# Altium schematic sheet Power.SchDoc
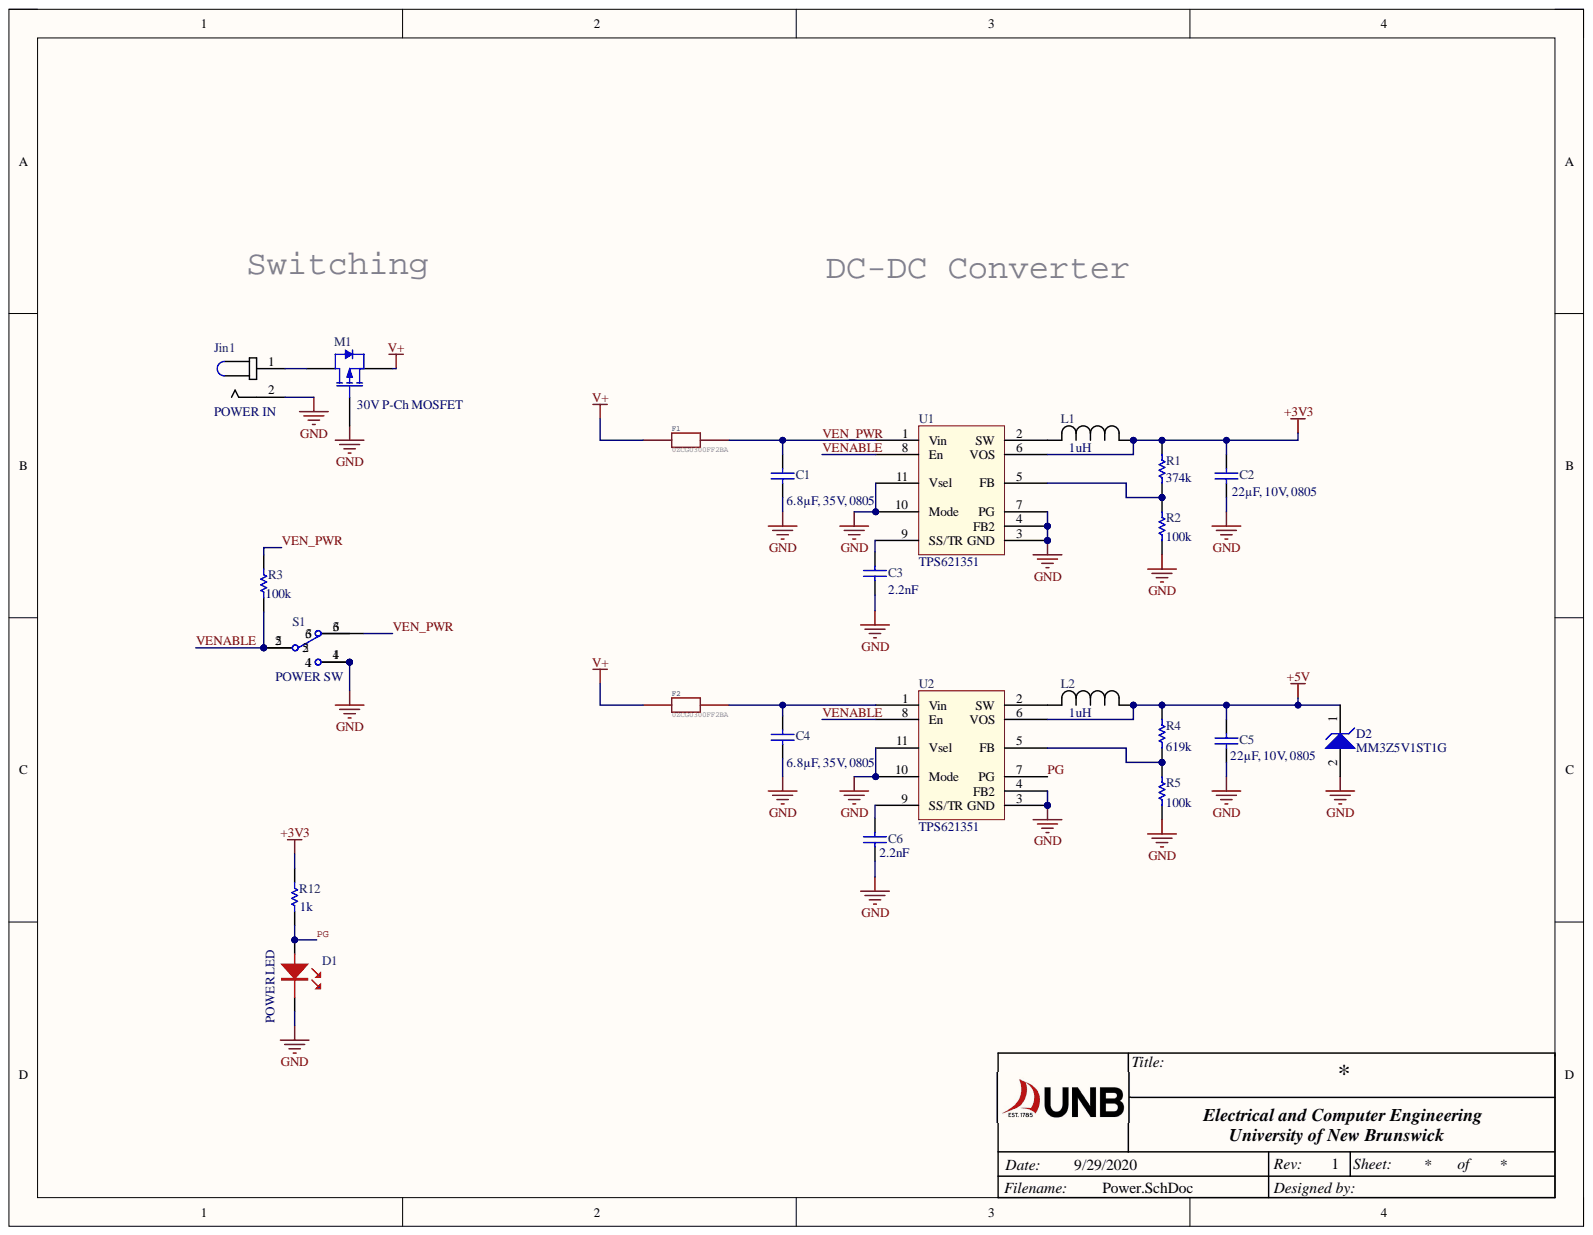
[diagram p0: Power.SchDoc]

## Parts
C1  6.8µF, 35V, 0805  [0805 Cap]
C2  22µF, 10V, 0805  [0805 Cap]
C3  2.2nF  [0603 Cap]
C4  6.8µF, 35V, 0805  [0805 Cap]
C5  22µF, 10V, 0805  [0805 Cap]
C6  2.2nF  [0603 Cap]
D1  POWER LED  [LED_0603_RED]
D2  MM3Z5V1ST1G  [ONSC-SOD-323-2-477-02_V]
F1  0ZCG0300FF2BA  [0ZCF]
F2  0ZCG0300FF2BA  [0ZCF]
Jin1  POWER IN  [CUI_PJ-063AH_PJ-063AH(Primary)]
L1  1uH  [ASPI-0530HI]
L2  1uH  [ASPI-0530HI]
M1  30V P-Ch MOSFET  Drain to Source Voltage (Vdss): 30V  [8-PowerVDFN]
R1  374k  [0603 Resistor]
R2  100k  [0603 Resistor]
R3  100k  [0603 Resistor]
R4  619k  [0603 Resistor]
R5  100k  [0603 Resistor]
R12  1k  [0603 Resistor]
S1  POWER SW  [EG1271A]
U1  TPS621351  [VQFN]
U2  TPS621351  [VQFN]

## Nets
+3V3: C2.2 L1.1 R1.1 R12.2 U1.6(VOS)
+5V: C5.2 D2.1 L2.1 R4.1 U2.6(VOS)
GND: C1.1 C2.1 C3.1 C4.1 C5.1 C6.1 D1.K D2.2 Jin1.2 M1.4 R2.2 R5.2 S1.1 S1.4 U1.3(GND) U1.4(FB2) U1.7(PG) U1.10(Mode) U1.11(Vsel) U2.3(GND) U2.4(FB2) U2.10(Mode) U2.11(Vsel)
V+: F1.P$1 F2.P$1 M1.1
PG: D1.A R12.1 U2.7(PG)
VEN_PWR: C1.2 F1.P$2 R3.1 S1.6 U1.1(Vin)
VENABLE: R3.2 S1.2 S1.5 U1.8(En) U2.8(En)
NetC3_2: C3.2 U1.9(SS/TR)
NetC4_2: C4.2 F2.P$2 U2.1(Vin)
NetC6_2: C6.2 U2.9(SS/TR)
NetJin1_1: Jin1.1 M1.5
NetL1_2: L1.2 U1.2(SW)
NetL2_2: L2.2 U2.2(SW)
NetR1_2: R1.2 R2.1 U1.5(FB)
NetR4_2: R4.2 R5.1 U2.5(FB)

Not connected: S1 3

## Library data of the parts
C1 C4 = Cap Semi: CAP CER 6.8UF 35V X5R 0805; Manufacturer: TDK; Manufacturer Part Number: C2012X5R1V685M125AC; Dielectric Material: Ceramic; Tolerance: 20%; Capacitance: 6.8uF; Case Code (Imperial): 0805; Case Code (Metric): 2012; Case/Package: 0805; Category: Ceramic Capacitors; Component Kind: Standard; ComponentLink1Description: http://www.avx.com/docs/Catalogs/cx7r.pdf; ComponentLink1URL: http://www.avx.com/docs/Catalogs/cx7r.pdf; ComponentLink2Description: https://datasheet.ciiva.com/14894/tdkmlcccaprange-14894343.pdf?src-supplier=Digi-Key; ComponentLink2URL: https://datasheet.ciiva.com/14894/tdkmlcccaprange-14894343.pdf?src-supplier=Digi-Key; ComponentLink3Description: https://datasheet.ciiva.com/14894/tdkmlcccaprange-14894346.pdf?src-supplier=Digi-Key; ComponentLink3URL: https://datasheet.ciiva.com/14894/tdkmlcccaprange-14894346.pdf?src-supplier=Digi-Key; ComponentLink4Description: https://datasheet.ciiva.com/14894/tdkmlcccaprange-14894348.pdf?src-supplier=Digi-Key; ComponentLink4URL: https://datasheet.ciiva.com/14894/tdkmlcccaprange-14894348.pdf?src-supplier=Digi-Key; Depth: 1.25mm; Dielectric: X5R; Lead Free: Lead Free; Length: 0.079inch; Library Name: CAN_Interface_Library.SCHLIB; Max Operating Temperature: 85°C; Min Operating Temperature: -55°C; Mount: Surface Mount; Package Quantity: 2000; Packaging: Tape and Reel; RoHS: Yes; Signal Integrity: Cap Semi; Simulation: CAP; Stock 1: 4110; Supplier 1: Digi-Key; Supplier Part Number 1: 445-14434-1-ND; Thickness: 0.057inch; Value: 6.8µF, 35V, 0805; Voltage Rating: 35V; Voltage Rating (DC): 35V; Width: 0.049inch
C2 C5 = Cap Semi: CAPACITOR, MLCC, X5R, 22UF, 10V, 0805; Product Range:C Series; Capacitance:22 F; Capacitance Tolerance: 20%; Dielectric Characteristic:X5R; Voltage Rating:10V; Ceramic Capacitor Case:0805 [2012 Metric]; Operating Temperature ;RoHS Compliant: Yes; Manufacturer: TDK; Manufacturer Part Number: C2012X5R1A226M085AC; Capacitance: 22uF; Case Code (Imperial): 0805; Case Code (Metric): 2012; Case/Package: 0805; Category: Ceramic Capacitors; Component Kind: Standard; ComponentLink1Description: http://www.avx.com/docs/Catalogs/cx7r.pdf; ComponentLink1URL: http://www.avx.com/docs/Catalogs/cx7r.pdf; ComponentLink2Description: https://datasheet.ciiva.com/24216/c2012x5r1a226m085ac-264883-24216482.pdf?src-supplier=Mouser; ComponentLink2URL: https://datasheet.ciiva.com/24216/c2012x5r1a226m085ac-264883-24216482.pdf?src-supplier=Mouser; ComponentLink3Description: https://datasheet.ciiva.com/26834/getdatasheetpartid-711053-26834411.pdf?src-supplier=Verical; ComponentLink3URL: https://datasheet.ciiva.com/26834/getdatasheetpartid-711053-26834411.pdf?src-supplier=Verical; ComponentLink4Description: https://datasheet.ciiva.com/6287/tdkmlcccaprange-6287141.pdf?src-supplier=Digi-Key; ComponentLink4URL: https://datasheet.ciiva.com/6287/tdkmlcccaprange-6287141.pdf?src-supplier=Digi-Key; ComponentLink5Description: https://datasheet.ciiva.com/16471/e412-c-16471846.pdf?src-supplier=Future+Electronics; ComponentLink5URL: https://datasheet.ciiva.com/16471/e412-c-16471846.pdf?src-supplier=Future+Electronics; ComponentLink6Description: https://datasheet.ciiva.com/6287/tdkmlcccaprange-6287144.pdf?src-supplier=Digi-Key; ComponentLink6URL: https://datasheet.ciiva.com/6287/tdkmlcccaprange-6287144.pdf?src-supplier=Digi-Key; ComponentLink7Description: https://datasheet.ciiva.com/6287/tdkmlcccaprange-6287147.pdf?src-supplier=Digi-Key; ComponentLink7URL: https://datasheet.ciiva.com/6287/tdkmlcccaprange-6287147.pdf?src-supplier=Digi-Key; Composition: Ceramic; Depth: 1.25mm; Dielectric: X5R; Dielectric Material: Ceramic; Height: 0.85mm; Lead Free: Lead Free; Length: 2mm; Library Name: CAN_Interface_Library.SCHLIB; Max Operating Temperature: 85°C; Min Operating Temperature: -55°C; Mount: Surface Mount; Package Quantity: 1; Packaging: Tape and Reel; Radiation Hardening: No; REACH SVHC: No SVHC; RoHS: Yes; Signal Integrity: Cap Semi; Simulation: CAP; Stock 1: 64294; Supplier 1: Digi-Key; Supplier Part Number 1: 445-7663-1-ND; Termination: SMD/SMT; Thickness: 0.037inch; Tolerance: 20%; Value: 22µF, 10V, 0805; Voltage Rating: 10V; Voltage Rating (DC): 10V; Weight: 0.000194oz; Width: 1.25mm
C3 C6 = Cap Semi: Cap Ceramic 0.0022uF 50VDC X7R 10% SMD 0603 Paper T/R; Manufacturer: Samsung; Manufacturer Part Number: CL10B222KB8NNNC; Voltage: 25V; Voltage Rating: 50V; Capacitance: 2.2nF; Case Code (Imperial): 0603; Case Code (Metric): 1608; Case/Package: 0603; Category: Ceramic Capacitors; Component Kind: Standard; ComponentLink1Description: http://www.avx.com/docs/Catalogs/cx7r.pdf; ComponentLink1URL: http://www.avx.com/docs/Catalogs/cx7r.pdf; ComponentLink2Description: https://datasheet.ciiva.com/15195/getdatasheetpartid-354051-15195736.pdf?src-supplier=Verical; ComponentLink2URL: https://datasheet.ciiva.com/15195/getdatasheetpartid-354051-15195736.pdf?src-supplier=Verical; ComponentLink3Description: https://datasheet.ciiva.com/29198/458008-da-01-en-vs-kondensator-0603-29198600.pdf?src-supplier=Conrad; ComponentLink3URL: https://datasheet.ciiva.com/29198/458008-da-01-en-vs-kondensator-0603-29198600.pdf?src-supplier=Conrad; ComponentLink4Description: https://datasheet.ciiva.com/26310/mlcc-catalogdownload2013-eng-26310312.pdf?src-supplier=Distrelec; ComponentLink4URL: https://datasheet.ciiva.com/26310/mlcc-catalogdownload2013-eng-26310312.pdf?src-supplier=Distrelec; Contact Plating: Tin; Depth: 0.8mm; Dielectric: X7R; Height: 0.8mm; Lead Free: Lead Free; Length: 1.6mm; Library Name: CAN_Interface_Library.SCHLIB; Material: Ceramic; Max Operating Temperature: 125°C; Min Operating Temperature: -55°C; Mount: Surface Mount; Package Quantity: 1; Packaging: Tape and Reel; Radiation Hardening: No; RoHS: Yes; Signal Integrity: Cap Semi; Simulation: CAP; Stock 1: 366327; Supplier 1: Digi-Key; Supplier Part Number 1: 1276-1110-1-ND; Thickness: 0.035inch; Tolerance: 10%; Value: 2.2nF; Voltage Rating (DC): 50V; Width: 0.031inch
D1 = LED_0603_RED: LED, Red, 630 nm, 1.9 V, 30 mA, 60 mcd; Manufacturer: Wurth Electronics; Manufacturer Part Number: 150060SS75000; Dominant Wavelength: 630nm; Forward Current: 30mA; Forward Voltage: 1.9V; Lens Style: Clear,Rectangular; Luminous Intensity: 60mcd; Test Current: 20mA; Viewing Angle: 140°; Case Code (Imperial): 0603; Case Code (Metric): 1608; Case/Package: 0603; Category: LEDs; Color: Red; Component Kind: Standard; ComponentLink1Description: https://datasheet.ciiva.com/23843/150060ss75000-23843248.pdf?src-supplier=Newark; ComponentLink1URL: https://datasheet.ciiva.com/23843/150060ss75000-23843248.pdf?src-supplier=Newark; ComponentLink2Description: https://datasheet.ciiva.com/29279/759429-da-01-en-chipled-0603-w-kl-s-rot-150060ss75000-29279208.pdf?src-supplier=Conrad; ComponentLink2URL: https://datasheet.ciiva.com/29279/759429-da-01-en-chipled-0603-w-kl-s-rot-150060ss75000-29279208.pdf?src-supplier=Conrad; ComponentLink3Description: https://datasheet.ciiva.com/48430/150060ss75000-eng-tds-48430042.pdf?src-supplier=Distrelec; ComponentLink3URL: https://datasheet.ciiva.com/48430/150060ss75000-eng-tds-48430042.pdf?src-supplier=Distrelec; Height: 0.7mm; Length: 1.6mm; Max Operating Temperature: 85°C; Min Operating Temperature: -40°C; Mount: Surface Mount; Package Quantity: 4000; Packaging: Tape and Reel; REACH SVHC: Unknown; RoHS: Yes; Stock 1: 15937; Supplier 1: Digi-Key; Supplier Part Number 1: 732-4979-1-ND; Wavelength: 630nm; Width: 0.8mm; Library Name: Arbury_SCH.SchLib
D2 = CMP-1060-00694-1: Zener Voltage Regulator, 300 mW, Tight Tolerance Portfolio, 2-Pin SOD-323, Pb-Free, Tape and Reel; Manufacturer: ON Semiconductor; Element Configuration: Single; ESD Protection: Yes; Forward Voltage: 900mV; Impedance: 60R; Material: Plastic; Max Power Dissipation: 200mW; Max Reverse Leakage Current: 2uA; Test Current: 5mA; Tolerance: 2%; Voltage Rating (DC): 5.1V; Zener Current: 10mA; Zener Voltage: 5.09V; Case/Package: SOD-323-2; Category: Zener Diodes; Component Kind: Standard; ComponentLink1Description: Manufacturer URL; ComponentLink1URL: http://www.onsemi.com/; ComponentLink2Description: Datasheet; ComponentLink2URL: http://www.onsemi.cn/pub_link/Collateral/MM3Z2V4ST1-D.PDF; ComponentLink3Description: Package Specification; ComponentLink3URL: http://www.onsemi.com/pub_link/Collateral/477-02.PDF.1; ComponentLink4Description: https://datasheet.ciiva.com/10630/mm3z2v4st1-d-10630224.pdf?src-supplier=Avnet; ComponentLink4URL: https://datasheet.ciiva.com/10630/mm3z2v4st1-d-10630224.pdf?src-supplier=Avnet; ComponentLink5Description: https://datasheet.ciiva.com/894/mm3z2v4st1-d-894646.pdf?src-supplier=Digi-Key; ComponentLink5URL: https://datasheet.ciiva.com/894/mm3z2v4st1-d-894646.pdf?src-supplier=Digi-Key; ComponentLink6Description: https://datasheet.ciiva.com/25928/mm3z2v4st1-d-25928233.pdf?src-supplier=Avnet+Europe; ComponentLink6URL: https://datasheet.ciiva.com/25928/mm3z2v4st1-d-25928233.pdf?src-supplier=Avnet+Europe; ComponentLink7Description: https://datasheet.ciiva.com/22626/1878251-22626909.pdf?src-supplier=Element14; ComponentLink7URL: https://datasheet.ciiva.com/22626/1878251-22626909.pdf?src-supplier=Element14; ComponentLink8Description: https://datasheet.ciiva.com/894/mm3z2v4st1-d-894647.pdf?src-supplier=Digi-Key; ComponentLink8URL: https://datasheet.ciiva.com/894/mm3z2v4st1-d-894647.pdf?src-supplier=Digi-Key; ComponentLink9Description: https://datasheet.ciiva.com/894/mm3z2v4st1-d-894648.pdf?src-supplier=Digi-Key; ComponentLink9URL: https://datasheet.ciiva.com/894/mm3z2v4st1-d-894648.pdf?src-supplier=Digi-Key; ComponentLink10Description: https://datasheet.ciiva.com/16397/mm3z2v4st1-d-16397690.pdf?src-supplier=Future+Electronics; ComponentLink10URL: https://datasheet.ciiva.com/16397/mm3z2v4st1-d-16397690.pdf?src-supplier=Future+Electronics; ComponentLink11Description: https://datasheet.ciiva.com/22626/1878251-22626906.pdf?src-supplier=Element14; ComponentLink11URL: https://datasheet.ciiva.com/22626/1878251-22626906.pdf?src-supplier=Element14; ComponentLink12Description: https://datasheet.ciiva.com/16473/mm3z2v4st1-d-16473517.pdf?src-supplier=Avnet+Asia; ComponentLink12URL: https://datasheet.ciiva.com/16473/mm3z2v4st1-d-16473517.pdf?src-supplier=Avnet+Asia; Contact Plating: Tin,Matte; Height: 1mm; Lead Free: Lead Free; Length: 1.8mm; Library Name: Altium Content Vault; Manufacturer Part Number: MM3Z5V1ST1G; Max Operating Temperature: 150°C; Min Operating Temperature: -65°C; Number of Pins: 2; Package Quantity: 3000; Packaging: Tape and Reel; Peak Reverse Current: 2uA; Power Dissipation: 200mW; Power Rating: 200mW; Radiation Hardening: No; REACH SVHC: No SVHC; RoHS: Yes; Stock 1: 204185; Supplier 1: Digi-Key; Supplier Part Number 1: MM3Z5V1ST1GOSCT-ND; Voltage Tolerance: 2%; Width: 1.35mm; Working Voltage: 5.1V
F1 F2 = 0ZCG0300FF2BA: PTC RESET FUSE 24V 2A 2920; Manufacturer: Bel; Manufacturer Part Number: 0ZCF0200AF2C; Max Current Rating: 40A; Time to Trip: 5s; Trip Current: 4A; Case Code (Imperial): 2920; Case Code (Metric): 7351; Category: PTC Resettable Fuses; Component Kind: Standard; ComponentLink1Description: https://datasheet.ciiva.com/18550/0zcf-18550655.pdf?src-supplier=Digi-Key; ComponentLink1URL: https://datasheet.ciiva.com/18550/0zcf-18550655.pdf?src-supplier=Digi-Key; Hold Current: 2A; Lead Free: Lead Free; Package Quantity: 1; Packaging: Cut Tape; RoHS: Yes; Stock 1: 32175; Supplier 1: Digi-Key; Supplier Part Number 1: 507-1749-1-ND; Library Name: BrilliantLabsEagleLibrary.IntLib
Jin1 = PJ-063AH: CONN PWR JACK 2X5.5MM SOLDER; Manufacturer: CUI; Current Rating: 8A; Gender: Male; Insulation Resistance: 100MR; Number of Conductors: 2; Shielding: Shielded; Voltage Rating: 24V; Category: Connectors; Component Kind: Standard; ComponentLink1Description: http://www.cui.com/Product/Resource/FuturePDF/PJ-063AH.pdf?src-supplier=Future+Electronics; ComponentLink1URL: http://www.cui.com/Product/Resource/FuturePDF/PJ-063AH.pdf?src-supplier=Future+Electronics; ComponentLink2Description: https://www.cui.com/product/resource/digikeypdf/pj-063ah.pdf?src-supplier=Digi-Key; ComponentLink2URL: https://www.cui.com/product/resource/digikeypdf/pj-063ah.pdf?src-supplier=Digi-Key; ComponentLink3Description: https://www.cui.com/product/resource/digikeypdf/pj-063ah.pdf?src-supplier=Verical; ComponentLink3URL: https://www.cui.com/product/resource/digikeypdf/pj-063ah.pdf?src-supplier=Verical; ComponentLink4Description: https://www.cui.com/product/resource/digikeypdf/pj-063ah.pdf?src-supplier=Mouser; ComponentLink4URL: https://www.cui.com/product/resource/digikeypdf/pj-063ah.pdf?src-supplier=Mouser; ComponentLink5Description: https://www.cui.com/product/resource/digikeypdf/pj-063ah.pdf?src-supplier=Onlinecomponents.com; ComponentLink5URL: https://www.cui.com/product/resource/digikeypdf/pj-063ah.pdf?src-supplier=Onlinecomponents.com; ComponentLink6Description: https://www.cui.com/product/resource/digikeypdf/pj-063ah.pdf?src-supplier=Arrow+Electronics; ComponentLink6URL: https://www.cui.com/product/resource/digikeypdf/pj-063ah.pdf?src-supplier=Arrow+Electronics; ComponentLink7Description: https://www.cui.com/product/resource/digikeypdf/pj-063ah.pdf?src-supplier=NAC+Semi; ComponentLink7URL: https://www.cui.com/product/resource/digikeypdf/pj-063ah.pdf?src-supplier=NAC+Semi; ComponentLink8Description: https://www.cui.com/product/resource/digikeypdf/pj-063ah.pdf?src-supplier=Quest; ComponentLink8URL: https://www.cui.com/product/resource/digikeypdf/pj-063ah.pdf?src-supplier=Quest; Connector Type: Jack; Contact Gender: Male; Contact Material: Copper; Contact Plating: Nickel; Flammability Rating: UL94 V-0; Housing Color: Black; Housing Material: Thermoplastic; Lead Free: Lead Free; Manufacturer Part Number: PJ-063AH; Max Operating Temperature: 85°C; Min Operating Temperature: -25°C; Mount: Through Hole; Orientation: Horizontal; Package Quantity: 500; RoHS: Yes; Stock 1: 7747; Supplier 1: Digi-Key; Supplier Part Number 1: CP-063AH-ND; Termination: Through Hole; Library Name: BrilliantLabs_SchLib.SchLib
L1 L2 = Inductor: Fixed Inductors 1uH 20% -55C +125C; Manufacturer: Abracon; Manufacturer Part Number: ASPI-0530HI-1R0M-T2; Core Material: Carbonyl Powder; Current Rating: 7A; DC Resistance (DCR): 14mR; Max DC Current: 11A; Shielding: Unshielded; Test Frequency: 100kHz; Case/Package: Nonstandard; Category: Fixed Inductors; Component Kind: Standard; ComponentLink1Description: https://datasheet.ciiva.com/6691/aspi-0530hi-6691054.pdf?src-supplier=Digi-Key; ComponentLink1URL: https://datasheet.ciiva.com/6691/aspi-0530hi-6691054.pdf?src-supplier=Digi-Key; ComponentLink2Description: https://datasheet.ciiva.com/6691/aspi-0530hi-6691055.pdf?src-supplier=Digi-Key; ComponentLink2URL: https://datasheet.ciiva.com/6691/aspi-0530hi-6691055.pdf?src-supplier=Digi-Key; Composition: Wirewound; Height: 0.118inch; Height - Seated (Max): 0.118inch; Inductance: 1uH; Length: 0.22inch; Max Operating Temperature: 125°C; Min Operating Temperature: -55°C; Mount: Surface Mount; Package Quantity: 2000; Packaging: Tape and Reel; RoHS: Yes; Saturation Current: 11A; Stock 1: 21020; Supplier 1: Digi-Key; Supplier Part Number 1: 535-13037-1-ND; Termination: SMD/SMT; Tolerance: 20%; Value: 1uH; Width: 0.205inch; Library Name: BrilliantLabs_SchLib.SchLib
M1 = MOSFET Pch: MOSFET P-CH 30V 3X3 DFN; Manufacturer: Alpha & Omega Semiconductor; Manufacturer Part Number: AONR21307; Continuous Drain Current (ID): 24A; Input Capacitance: 1.995nF; Max Power Dissipation: 28W; Rds On Max: 11mR; Category: MOSFETs; Component Kind: Standard; ComponentLink1Description: http://www.aosmd.com/res/data_sheets/AONR21307.pdf?src-supplier=Digi-Key; ComponentLink1URL: http://www.aosmd.com/res/data_sheets/AONR21307.pdf?src-supplier=Digi-Key; Max Operating Temperature: 150°C; Min Operating Temperature: -55°C; Mount: Surface Mount; Number of Pins: 8; Packaging: Tape and Reel; RoHS: No; Stock 1: 3785; Supplier 1: Digi-Key; Supplier Part Number 1: 785-1796-1-ND; Library Name: BrilliantLabs_SchLib.SchLib
R1 = Res Semi: RES 374K OHM 1% 1/10W 0603; Manufacturer: Stackpole Electronics; Manufacturer Part Number: RMCF0603FT374K; Max Power Dissipation: 100mW; Resistance: 374kR; Temperature Coefficient: 100ppm/°C; Case Code (Imperial): 0603; Case Code (Metric): 1608; Case/Package: 0603; Category: Chip SMD Resistors; Component Kind: Standard; ComponentLink1Description: http://www.rohm.com/web/global/datasheet/ESR01MZPF/esr-e; ComponentLink1URL: http://www.rohm.com/web/global/datasheet/ESR01MZPF/esr-e; ComponentLink2Description: http://components.arrow.com/part/dataview/50501841S601819N1174?src-supplier=Arrow+Electronics; ComponentLink2URL: http://components.arrow.com/part/dataview/50501841S601819N1174?src-supplier=Arrow+Electronics; ComponentLink3Description: https://www.seielect.com/Catalog/SEI-RMCF_RMCP.pdf?src-supplier=Digi-Key; ComponentLink3URL: https://www.seielect.com/Catalog/SEI-RMCF_RMCP.pdf?src-supplier=Digi-Key; ComponentLink4Description: https://www.seielect.com/catalog/SEI-RMCF_RMCP.pdf?src-supplier=Avnet; ComponentLink4URL: https://www.seielect.com/catalog/SEI-RMCF_RMCP.pdf?src-supplier=Avnet; Composition: Thick Film; Contact Plating: Tin,Matte; Depth: 0.8mm; Features: Automotive AEC-Q200; Height: 0.022inch; Lead Free: Contains Lead; Length: 0.061inch; Max Operating Temperature: 155°C; Military Standard: Not; Min Operating Temperature: -55°C; Mount: Surface Mount; Number of Terminations: 2; Package Quantity: 5000; Packaging: Tape and Reel; Radiation Hardening: No; RoHS: Yes; Signal Integrity: Res Semi; Simulation: RES; Stock 1: 11056; Supplier 1: Digi-Key; Supplier Part Number 1: RMCF0603FT374KCT-ND; Tolerance: 1%; Value: 374k; Width: 0.031inch; Library Name: BrillianBit.SCHLIB
R2 R3 R5 = Res Semi: RES 100K OHM 1% 1/10W 0603; Manufacturer: Stackpole Electronics; Manufacturer Part Number: RMCF0603FT100K; Max Power Dissipation: 100mW; Resistance: 100kR; Temperature Coefficient: 100ppm/°C; Working Voltage: 75V; Case Code (Imperial): 0603; Case Code (Metric): 1608; Case/Package: 0603; Category: Chip SMD Resistors; Component Kind: Standard; ComponentLink1Description: http://www.rohm.com/web/global/datasheet/ESR01MZPF/esr-e; ComponentLink1URL: http://www.rohm.com/web/global/datasheet/ESR01MZPF/esr-e; ComponentLink2Description: https://datasheet.ciiva.com/15193/getdatasheetpartid-362597-15193437.pdf?src-supplier=Verical; ComponentLink2URL: https://datasheet.ciiva.com/15193/getdatasheetpartid-362597-15193437.pdf?src-supplier=Verical; Composition: Thick Film; Contact Plating: Tin,Matte; Depth: 0.8mm; Features: Automotive AEC-Q200; Height: 0.022inch; Lead Free: Lead Free; Length: 1.55mm; Max Operating Temperature: 155°C; Military Standard: Not; Min Operating Temperature: -55°C; Mount: Surface Mount; Number of Terminations: 2; Packaging: Tape and Reel; Power Rating: 100mW; Radiation Hardening: No; RoHS: Yes; Signal Integrity: Res Semi; Simulation: RES; Stock 1: 2666095; Supplier 1: Digi-Key; Supplier Part Number 1: RMCF0603FT100KDKR-ND; Tolerance: 1%; Value: 100k; Width: 0.031inch; Library Name: BrillianBit.SCHLIB
R4 = Res Semi: RES SMD 619K OHM 1% 1/10W 0603; Manufacturer: Stackpole Electronics; Manufacturer Part Number: RMCF0603FT619K; Max Power Dissipation: 100mW; Resistance: 619kR; Temperature Coefficient: 100ppm/°C; Case Code (Imperial): 0603; Case Code (Metric): 1608; Case/Package: 0603; Category: Chip SMD Resistors; Component Kind: Standard; ComponentLink1Description: http://www.rohm.com/web/global/datasheet/ESR01MZPF/esr-e; ComponentLink1URL: http://www.rohm.com/web/global/datasheet/ESR01MZPF/esr-e; ComponentLink2Description: https://www.seielect.com/catalog/SEI-RMCF_RMCP.pdf?src-supplier=Avnet; ComponentLink2URL: https://www.seielect.com/catalog/SEI-RMCF_RMCP.pdf?src-supplier=Avnet; ComponentLink3Description: http://www.seielect.com/Catalog/SEI-RMC.pdf?src-supplier=Future+Electronics; ComponentLink3URL: http://www.seielect.com/Catalog/SEI-RMC.pdf?src-supplier=Future+Electronics; ComponentLink4Description: https://www.seielect.com/catalog/SEI-Packaging.pdf?src-supplier=Digi-Key; ComponentLink4URL: https://www.seielect.com/catalog/SEI-Packaging.pdf?src-supplier=Digi-Key; ComponentLink5Description: https://www.seielect.com/Catalog/SEI-RMCF_RMCP.pdf?src-supplier=Digi-Key; ComponentLink5URL: https://www.seielect.com/Catalog/SEI-RMCF_RMCP.pdf?src-supplier=Digi-Key; Composition: Thick Film; Contact Plating: Tin,Matte; Features: Automotive AEC-Q200; Height: 0.022inch; Lead Free: Lead Free; Length: 0.061inch; Max Operating Temperature: 155°C; Min Operating Temperature: -55°C; Mount: Surface Mount; Number of Terminations: 2; Packaging: Tape and Reel; Power Rating: 100mW; Radiation Hardening: No; RoHS: Yes; Signal Integrity: Res Semi; Simulation: RES; Stock 1: 7746; Supplier 1: Digi-Key; Supplier Part Number 1: RMCF0603FT619KCT-ND; Tolerance: 1%; Value: 619k; Width: 0.031inch; Library Name: BrillianBit.SCHLIB
R12 = Res Semi: Res Thick Film 0603 1K Ohm 1% 0.1W(1/10W) ±100ppm/C Pad SMD Automotive T/R; Manufacturer: Stackpole Electronics; Manufacturer Part Number: RMCF0603FT1K00; Max Power Dissipation: 100mW; Resistance: 1kR; Temperature Coefficient: 100ppm/°C; Case Code (Imperial): 0603; Case Code (Metric): 1608; Case/Package: 0603; Category: Chip SMD Resistors; Component Kind: Standard; ComponentLink1Description: http://www.rohm.com/web/global/datasheet/ESR01MZPF/esr-e; ComponentLink1URL: http://www.rohm.com/web/global/datasheet/ESR01MZPF/esr-e; ComponentLink2Description: https://www.seielect.com/catalog/SEI-Packaging.pdf?src-supplier=Avnet; ComponentLink2URL: https://www.seielect.com/catalog/SEI-Packaging.pdf?src-supplier=Avnet; ComponentLink3Description: https://www.seielect.com/catalog/sei-rmcf_rmcp.pdf?src-supplier=Digi-Key; ComponentLink3URL: https://www.seielect.com/catalog/sei-rmcf_rmcp.pdf?src-supplier=Digi-Key; ComponentLink4Description: https://www.seielect.com/catalog/SEI-RMCF_RMCP.pdf?src-supplier=Avnet; ComponentLink4URL: https://www.seielect.com/catalog/SEI-RMCF_RMCP.pdf?src-supplier=Avnet; ComponentLink5Description: http://www.seielect.com/Catalog/SEI-rmc.pdf?src-supplier=Future+Electronics; ComponentLink5URL: http://www.seielect.com/Catalog/SEI-rmc.pdf?src-supplier=Future+Electronics; ComponentLink6Description: https://www.seielect.com/catalog/SEI-RMCF_RMCP.pdf?src-supplier=Avnet+Asia; ComponentLink6URL: https://www.seielect.com/catalog/SEI-RMCF_RMCP.pdf?src-supplier=Avnet+Asia; ComponentLink7Description: https://www.seielect.com/catalog/SEI-Packaging.pdf?src-supplier=Verical; ComponentLink7URL: https://www.seielect.com/catalog/SEI-Packaging.pdf?src-supplier=Verical; ComponentLink8Description: https://www.seielect.com/catalog/SEI-Packaging.pdf?src-supplier=Arrow+Electronics; ComponentLink8URL: https://www.seielect.com/catalog/SEI-Packaging.pdf?src-supplier=Arrow+Electronics; ComponentLink9Description: https://www.seielect.com/catalog/SEI-Packaging.pdf?src-supplier=Quest; ComponentLink9URL: https://www.seielect.com/catalog/SEI-Packaging.pdf?src-supplier=Quest; Composition: Thick Film; Contact Plating: Tin,Matte; Depth: 0.8mm; Features: Automotive AEC-Q200; Height: 0.022inch; Lead Free: Lead Free; Length: 1.55mm; Max Operating Temperature: 155°C; Military Standard: Not; Min Operating Temperature: -55°C; Mount: Surface Mount; Number of Terminations: 2; Packaging: Tape and Reel; Power Rating: 100mW; Radiation Hardening: No; RoHS: Yes; Signal Integrity: Res Semi; Simulation: RES; Stock 1: 4210775; Supplier 1: Digi-Key; Supplier Part Number 1: RMCF0603FT1K00CT-ND; Tolerance: 1%; Value: 1k; Width: 0.031inch; Library Name: BrillianBit.SCHLIB
S1 = SW-SPDT: SWITCH SLIDE SPDT 300MA 30V; Manufacturer: E-Switch; Manufacturer Part Number: EG1271; Actuator Length: 2mm; Actuator Type: Standard; Contact Style: Non-Shorting; Electrical Life: 10000Cycles; Illumination Type: Non-Illuminated; Operating Force: 200gf; Switch Function: On-On; Throw Configuration: SPDT,DPDT; Category: Slide Switches; Component Kind: Standard; ComponentLink1Description: http://spec_sheets.e-switch.com/specs/P040047.pdf; ComponentLink1URL: http://spec_sheets.e-switch.com/specs/P040047.pdf; ComponentLink2Description: https://datasheet.ciiva.com/16174/p040046-16174279.pdf?src-supplier=Onlinecomponents.com; ComponentLink2URL: https://datasheet.ciiva.com/16174/p040046-16174279.pdf?src-supplier=Onlinecomponents.com; ComponentLink3Description: https://datasheet.ciiva.com/6967/p040046e-6967523.pdf?src-supplier=Newark; ComponentLink3URL: https://datasheet.ciiva.com/6967/p040046e-6967523.pdf?src-supplier=Newark; ComponentLink4Description: https://datasheet.ciiva.com/2889/p040046-2889380.pdf?src-supplier=Digi-Key; ComponentLink4URL: https://datasheet.ciiva.com/2889/p040046-2889380.pdf?src-supplier=Digi-Key; ComponentLink5Description: https://datasheet.ciiva.com/16297/eg-16297513.pdf?src-supplier=Future+Electronics; ComponentLink5URL: https://datasheet.ciiva.com/16297/eg-16297513.pdf?src-supplier=Future+Electronics; Contact Current Rating: 300mA; Contact Material: Copper Alloy,Copper,Silver; Contact Plating: Silver; Current Rating: 300mA; Lead Free: Lead Free; Max Operating Temperature: 70°C; Max Voltage Rating (DC): 30V; Min Operating Temperature: -25°C; Mount: Through Hole; Orientation: Straight; Package Quantity: 1000; Packaging: Bulk; REACH SVHC: No SVHC; RoHS: Yes; Stock 1: 963; Supplier 1: Digi-Key; Supplier Part Number 1: EG1918-ND; Switch Travel: 2mm; Termination: PC Pin; Voltage Rating (AC): 30V; Voltage Rating (DC): 30V; Library Name: BrilliantLabs_SchLib.SchLib
U1 U2 = TPS621351: IC REG BUCK ADJ 3.5A 11VQFN; Manufacturer: Texas Instruments; Manufacturer Part Number: TPS621351RGXT; Max Input Voltage: 17V; Max Output Voltage: 12V; Min Input Voltage: 3V; Min Output Voltage: 800mV; Number of Outputs: 1; Output Configuration: Positive; Output Type: Adjustable; Quiescent Current: 18uA; Switching Frequency: 2.5MHz; Synchronous Rectifier: Yes; Case/Package: VQFN; Category: Voltage Regulators - Switching; Component Kind: Standard; ComponentLink1Description: http://www.ti.com/lit/ds/symlink/tps62135.pdf?src-supplier=Digi-Key; ComponentLink1URL: http://www.ti.com/lit/ds/symlink/tps62135.pdf?src-supplier=Digi-Key; Lead Free: Lead Free; Max Operating Temperature: 125°C; Min Operating Temperature: -40°C; Mount: Surface Mount; Number of Pins: 11; Output Current: 3.5A; Packaging: Tape and Reel; RoHS: Yes; Stock 1: 84; Supplier 1: Digi-Key; Supplier Part Number 1: 296-44877-1-ND; Library Name: BrilliantLabs_SchLib.SchLib
